# Altium schematic sheet LogicsOnly_Main.SchDoc
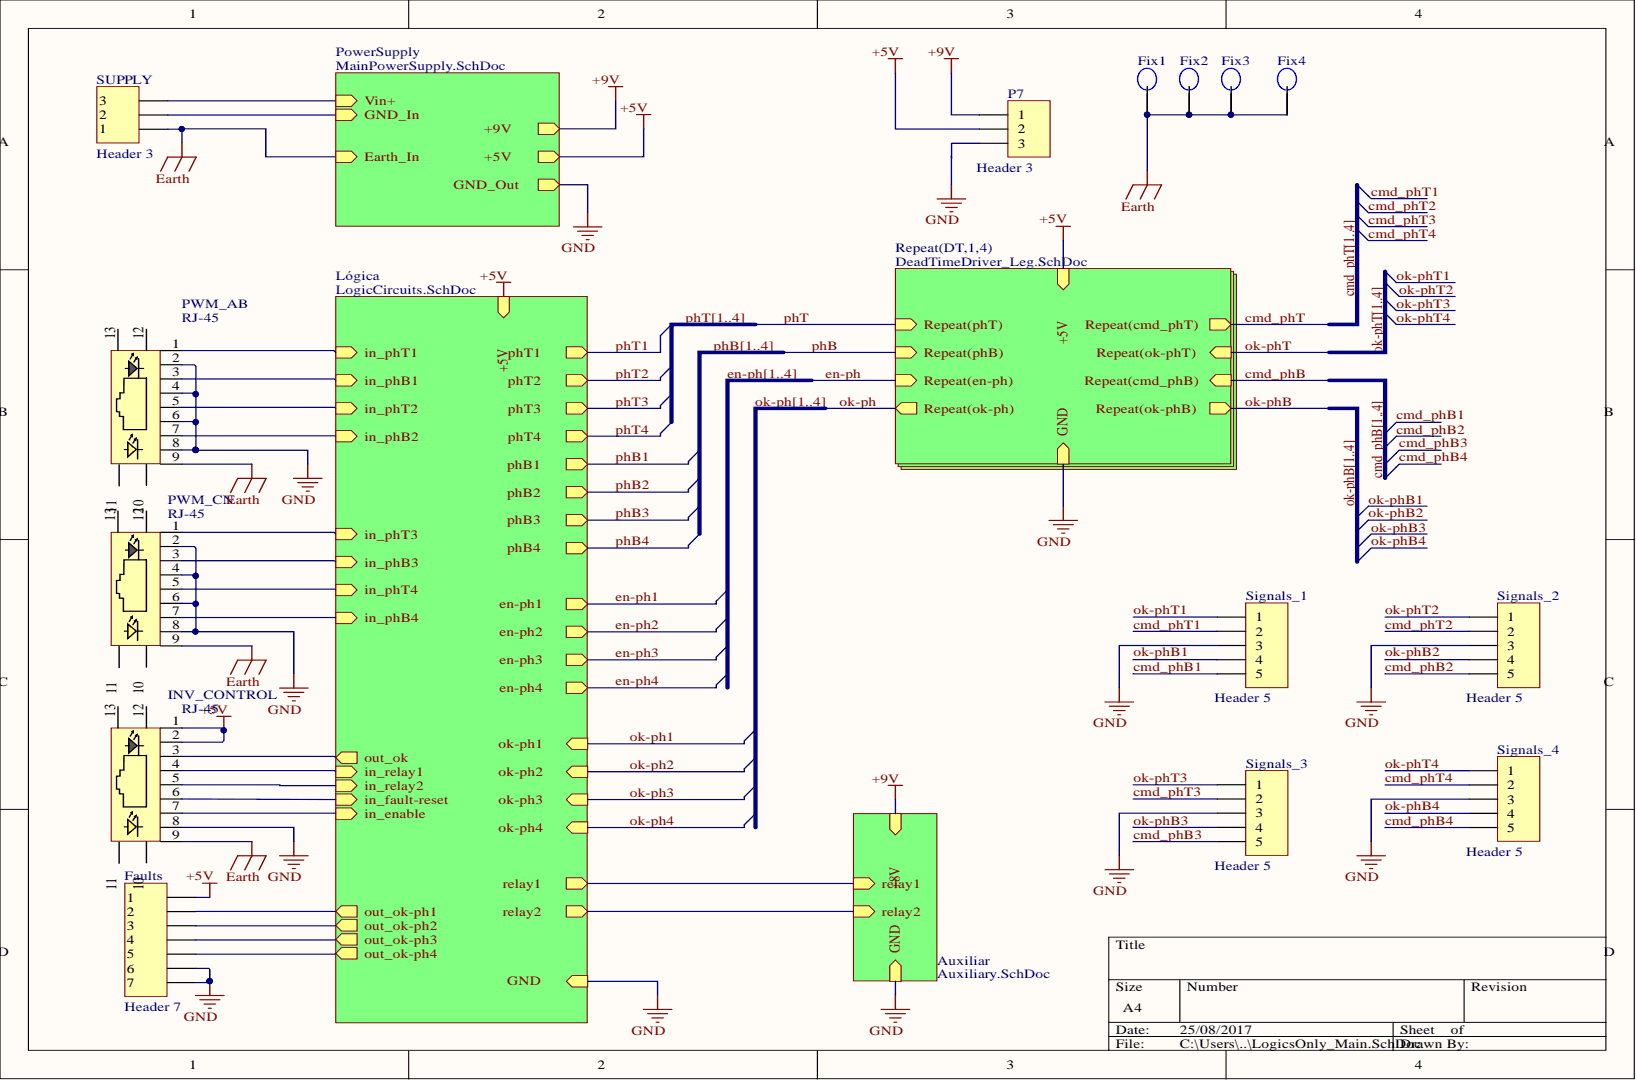
[diagram p0: LogicsOnly_Main.SchDoc]

## Parts
Faults  value Header 7  [HDR1X7]
Fix1  (no value)  [Pino]
Fix2  (no value)  [Pino]
Fix3  (no value)  [Pino]
Fix4  (no value)  [Pino]
INV_CONTROL  value RJ-45  [RJ-45]
P7  value Header 3  [HDR1X3]
PWM_AB  value RJ-45  [RJ-45]
PWM_CN  value RJ-45  [RJ-45]
Signals_1  value Header 5  [HDR1X5]
Signals_2  value Header 5  [HDR1X5]
Signals_3  value Header 5  [HDR1X5]
Signals_4  value Header 5  [HDR1X5]
SUPPLY  value Header 3  [3 Pin  Borner]

## Nets
+5V: Faults.1 INV_CONTROL.1 INV_CONTROL.2 P7.2
+9V: P7.1
EARTH: Fix1.0 Fix2.0 Fix3.0 Fix4.0 INV_CONTROL.9 PWM_AB.9 PWM_CN.9 SUPPLY.1
GND: Faults.6 Faults.7 INV_CONTROL.8 P7.3 PWM_AB.2 PWM_AB.4 PWM_AB.6 PWM_AB.8 PWM_CN.2 PWM_CN.4 PWM_CN.6 PWM_CN.8 Signals_1.3 Signals_2.3 Signals_3.3 Signals_4.3
CMD_PHB1: Signals_1.5  [also labelled CMD_PHB]
CMD_PHB2: Signals_2.5  [also labelled CMD_PHB]
CMD_PHB3: Signals_3.5  [also labelled CMD_PHB]
CMD_PHB4: Signals_4.5  [also labelled CMD_PHB]
CMD_PHT1: Signals_1.2  [also labelled CMD_PHT]
CMD_PHT2: Signals_2.2  [also labelled CMD_PHT]
CMD_PHT3: Signals_3.2  [also labelled CMD_PHT]
CMD_PHT4: Signals_4.2  [also labelled CMD_PHT]
OK-PHB1: Signals_1.4  [also labelled OK-PHB]
OK-PHB2: Signals_2.4  [also labelled OK-PHB]
OK-PHB3: Signals_3.4  [also labelled OK-PHB]
OK-PHB4: Signals_4.4  [also labelled OK-PHB]
OK-PHT1: Signals_1.1  [also labelled OK-PHT]
OK-PHT2: Signals_2.1  [also labelled OK-PHT]
OK-PHT3: Signals_3.1  [also labelled OK-PHT]
OK-PHT4: Signals_4.1  [also labelled OK-PHT]
NetFaults_2: Faults.2
NetFaults_3: Faults.3
NetFaults_4: Faults.4
NetFaults_5: Faults.5
NetINV_CONTROL_3: INV_CONTROL.3
NetINV_CONTROL_4: INV_CONTROL.4
NetINV_CONTROL_5: INV_CONTROL.5
NetINV_CONTROL_6: INV_CONTROL.6
NetINV_CONTROL_7: INV_CONTROL.7
NetPWM_AB_1: PWM_AB.1
NetPWM_AB_3: PWM_AB.3
NetPWM_AB_5: PWM_AB.5
NetPWM_AB_7: PWM_AB.7
NetPWM_CN_1: PWM_CN.1
NetPWM_CN_3: PWM_CN.3
NetPWM_CN_5: PWM_CN.5
NetPWM_CN_7: PWM_CN.7
EN-PH1:  [also labelled EN-PH]
EN-PH2:  [also labelled EN-PH]
EN-PH3:  [also labelled EN-PH]
EN-PH4:  [also labelled EN-PH]
OK-PH1:  [also labelled OK-PH]
OK-PH2:  [also labelled OK-PH]
OK-PH3:  [also labelled OK-PH]
OK-PH4:  [also labelled OK-PH]
PHB1:  [also labelled PHB]
PHB2:  [also labelled PHB]
PHB3:  [also labelled PHB]
PHB4:  [also labelled PHB]
PHT1:  [also labelled PHT]
PHT2:  [also labelled PHT]
PHT3:  [also labelled PHT]
PHT4:  [also labelled PHT]
RELAY1:
RELAY2:

Not connected: INV_CONTROL 10 11 12 13; PWM_AB 10 11 12 13; PWM_CN 10 11 12 13; SUPPLY 2 3

## Library data of the parts
Faults = Header 7: Header, 7-Pin; Component Kind: Standard; LatestRevisionDate: 17-Jul-2002; LatestRevisionNote: Re-released for DXP Platform.; Library Name: Miscellaneous Connectors.IntLib; Signal Integrity: Connector; Publisher: Altium Limited
Fix1-Fix4 = Pino: Value: Pin; Component Kind: Standard; Library Name: Sch_LCEE.SchLib
INV_CONTROL PWM_AB PWM_CN = RJ-45: Component Kind: Standard; Library Name: Sch_LCEE.SchLib
P7 SUPPLY = Header 3: Header, 3-Pin; Component Kind: Standard; LatestRevisionDate: 17-Jul-2002; LatestRevisionNote: Re-released for DXP Platform.; Library Name: AQAC.SCHLIB; Publisher: Altium Limited
Signals_1-Signals_4 = Header 5: Header, 5-Pin; Component Kind: Standard; LatestRevisionDate: 17-Jul-2002; LatestRevisionNote: Re-released for DXP Platform.; Library Name: Miscellaneous Connectors.IntLib; Signal Integrity: Connector; Publisher: Altium Limited
